# Altium schematic sheet 22_ATK_RK3588S_M2WIFI.SchDoc
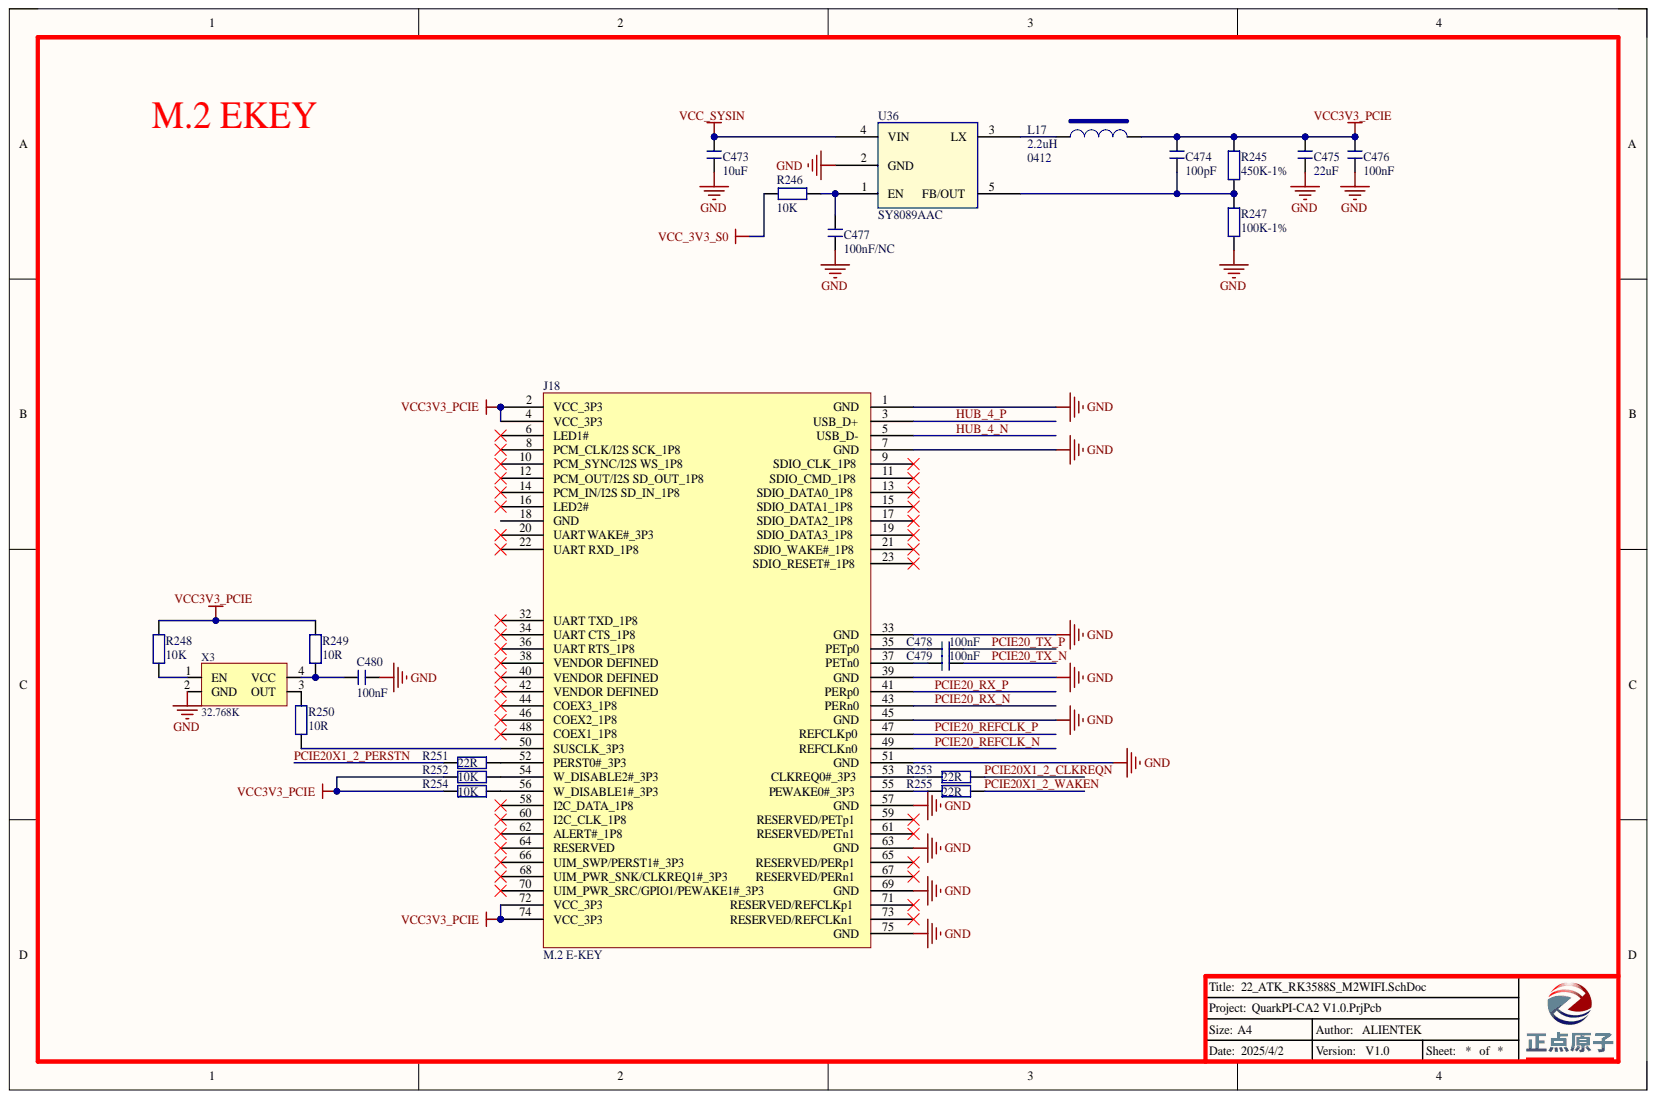
[diagram p0: 22_ATK_RK3588S_M2WIFI.SchDoc]

## Parts
C473  10uF  [C 0402]
C474  100pF  [C 0201]
C475  22uF  [C 0603]
C476  100nF  [C 0201]
C477  100nF/NC  [C 0201]
C478  100nF  [C 0201]
C479  100nF  [C 0201]
C480  100nF  [C 0201]
J18  M.2 E-KEY  [M.2 E-key_1]
L17  2.2uH  [L 0412]
R245  450K-1%  [R 0402]
R246  10K  [R 0402]
R247  100K-1%  [R 0402]
R248  10K  [R 0402]
R249  10R  [R 0402]
R250  10R  [R 0402]
R251  22R  [R 0402]
R252  10K  [R 0402]
R253  22R  [R 0402]
R254  10K  [R 0402]
R255  22R  [R 0402]
U36  SY8089AAC  [SOT_23_5]
X3  32.768K  [XTAL-3225 - S]

## Nets
GND: C473.1 C475.1 C476.1 C477.1 C480.1 J18.1(GND) J18.7(GND) J18.33(GND) J18.39(GND) J18.45(GND) J18.51(GND) J18.57(GND) J18.63(GND) J18.69(GND) J18.75(GND) R247.1 U36.2(GND) X3.2(GND)
VCC3V3_PCIE: C474.2 C475.2 C476.2 J18.2(VCC_3P3) J18.4(VCC_3P3) J18.72(VCC_3P3) J18.74(VCC_3P3) L17.2 R245.2 R248.2 R249.2 R252.2 R254.2
VCC_3V3_S0: R246.1
VCC_SYSIN: C473.2 U36.4(VIN)
HUB_4_N: J18.5(USB_D-)
HUB_4_P: J18.3(USB_D+)
PCIE20_REFCLK_N: J18.49(REFCLKn0)
PCIE20_REFCLK_P: J18.47(REFCLKp0)
PCIE20_RX_N: J18.43(PERn0)
PCIE20_RX_P: J18.41(PERp0)
PCIE20_TX_N: C479.1
PCIE20_TX_P: C478.1
PCIE20X1_2_CLKREQN: R253.1
PCIE20X1_2_PERSTN: R251.2
PCIE20X1_2_WAKEN: R255.1
NetC474_1: C474.1 R245.1 R247.2 U36.5(FB/OUT)
NetC477_2: C477.2 R246.2 U36.1(EN)
NetC478_2: C478.2 J18.35(PETp0)
NetC479_2: C479.2 J18.37(PETn0)
NetC480_2: C480.2 R249.1 X3.4(VCC)
NetJ18_50: J18.50(SUSCLK_3P3) R250.1
NetJ18_52: J18.52(PERST0#_3P3) R251.1
NetJ18_53: J18.53(CLKREQ0#_3P3) R253.2
NetJ18_54: J18.54(W_DISABLE2#_3P3) R252.1
NetJ18_55: J18.55(PEWAKE0#_3P3) R255.2
NetJ18_56: J18.56(W_DISABLE1#_3P3) R254.1
NetL17_1: L17.1 U36.3(LX)
NetR248_1: R248.1 X3.1(EN)
NetR250_2: R250.2 X3.3(OUT)

Not connected: J18 6(LED1#) 8(PCM_CLK/I2S_SCK_1P8) 9(SDIO_CLK_1P8) 10(PCM_SYNC/I2S_WS_1P8) 11(SDIO_CMD_1P8) 12(PCM_OUT/I2S_SD_OUT_1P8) 13(SDIO_DATA0_1P8) 14(PCM_IN/I2S_SD_IN_1P8) 15(SDIO_DATA1_1P8) 16(LED2#) 17(SDIO_DATA2_1P8) 18(GND) 19(SDIO_DATA3_1P8) 20(UART_WAKE#_3P3) 21(SDIO_WAKE#_1P8) 22(UART_RXD_1P8) 23(SDIO_RESET#_1P8) 32(UART_TXD_1P8) 34(UART_CTS_1P8) 36(UART_RTS_1P8) 38(VENDOR_DEFINED) 40(VENDOR_DEFINED) 42(VENDOR_DEFINED) 44(COEX3_1P8) 46(COEX2_1P8) 48(COEX1_1P8) 58(I2C_DATA_1P8) 59(RESERVED/PETp1) 60(I2C_CLK_1P8) 61(RESERVED/PETn1) 62(ALERT#_1P8) 64(RESERVED) 65(RESERVED/PERp1) 66(UIM_SWP/PERST1#_3P3) 67(RESERVED/PERn1) 68(UIM_PWR_SNK/CLKREQ1#_3P3) 70(UIM_PWR_SRC/GPIO1/PEWAKE1#_3P3) 71(RESERVED/REFCLKp1) 73(RESERVED/REFCLKn1)

## Library data of the parts
C473-C480 = C: 无极性电容; BOM名称: 无极性贴片电容; 版权归属: 正点原子; Component Kind: Standard; Library Name: 正点原子封装库V1.0.IntLib; 学习资源: www.openedv.com
L17 = INDUCTORS OF FERRITE_Dup1: FXL0412-2R2-M; Manufacturer: sunlord; Manufacturer PN: SWPA4026S2R2MT; DC Resistor: 0.04ohm; Irms: 2A; Isat: 2.10A; PCB Footprint: 0412; Priority: A; Resonace frequency: 96M; Tolerance: 20%; Component Kind: Standard; Library Name: ATK-CLRK3588B.IntLib; Part Type: POWER_IND; RK PN: SWPA4026S2R2MT; Created by: YTL
R245-R255 = R: 电阻; BOM名称: 贴片电阻; 版权归属: 正点原子; Component Kind: Standard; Library Name: 正点原子封装库V1.0.IntLib; Parameter: 电阻; 学习资源: www.openedv.com
U36 = DCDC_SY8088: High Efficiency 5.5V, 2A continuous, 3A peak, 1MHz Synchronous Step Down Regulator; Manufacturer: Silergy（矽力杰）; PCB Footprint: /ETA3409; Priority: A; Component Kind: Standard; Library Name: ATK-CLRK3588B.IntLib; Manufacturer PN: SY8089AAC; Part Type: DCDC; RK PN: SY8089AAC; Created by: JJJ
X3 = XTAL-4P_S: 4脚有源晶振; BOM名称: 4脚晶振; 版权归属: 正点原子; Component Kind: Standard; Library Name: ATK-DLRK3588B V0.2.IntLib; 学习资源: www.openedv.com
J18 = M.2 E-KEY: Component Kind: Standard; Library Name: ATK-DLRK3588B V0.2.IntLib
